# Altium schematic sheet K66_Sheet2.SchDoc
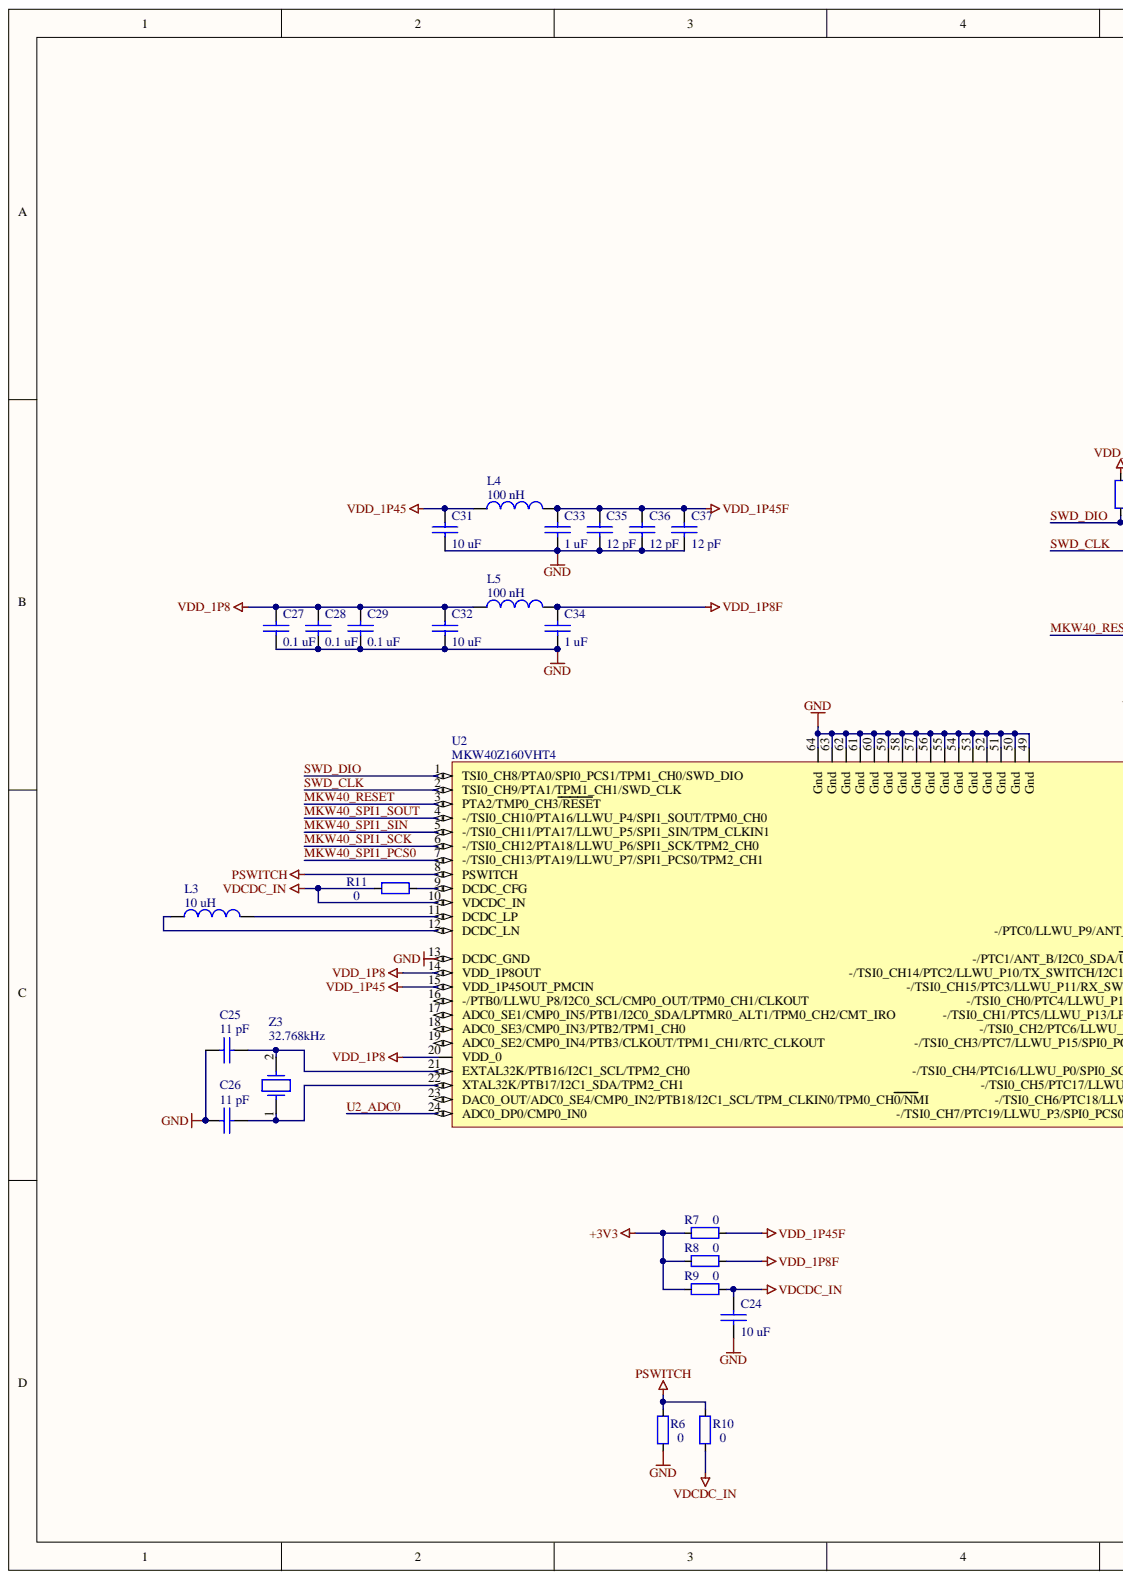
[diagram p0: K66_Sheet2.SchDoc, left]
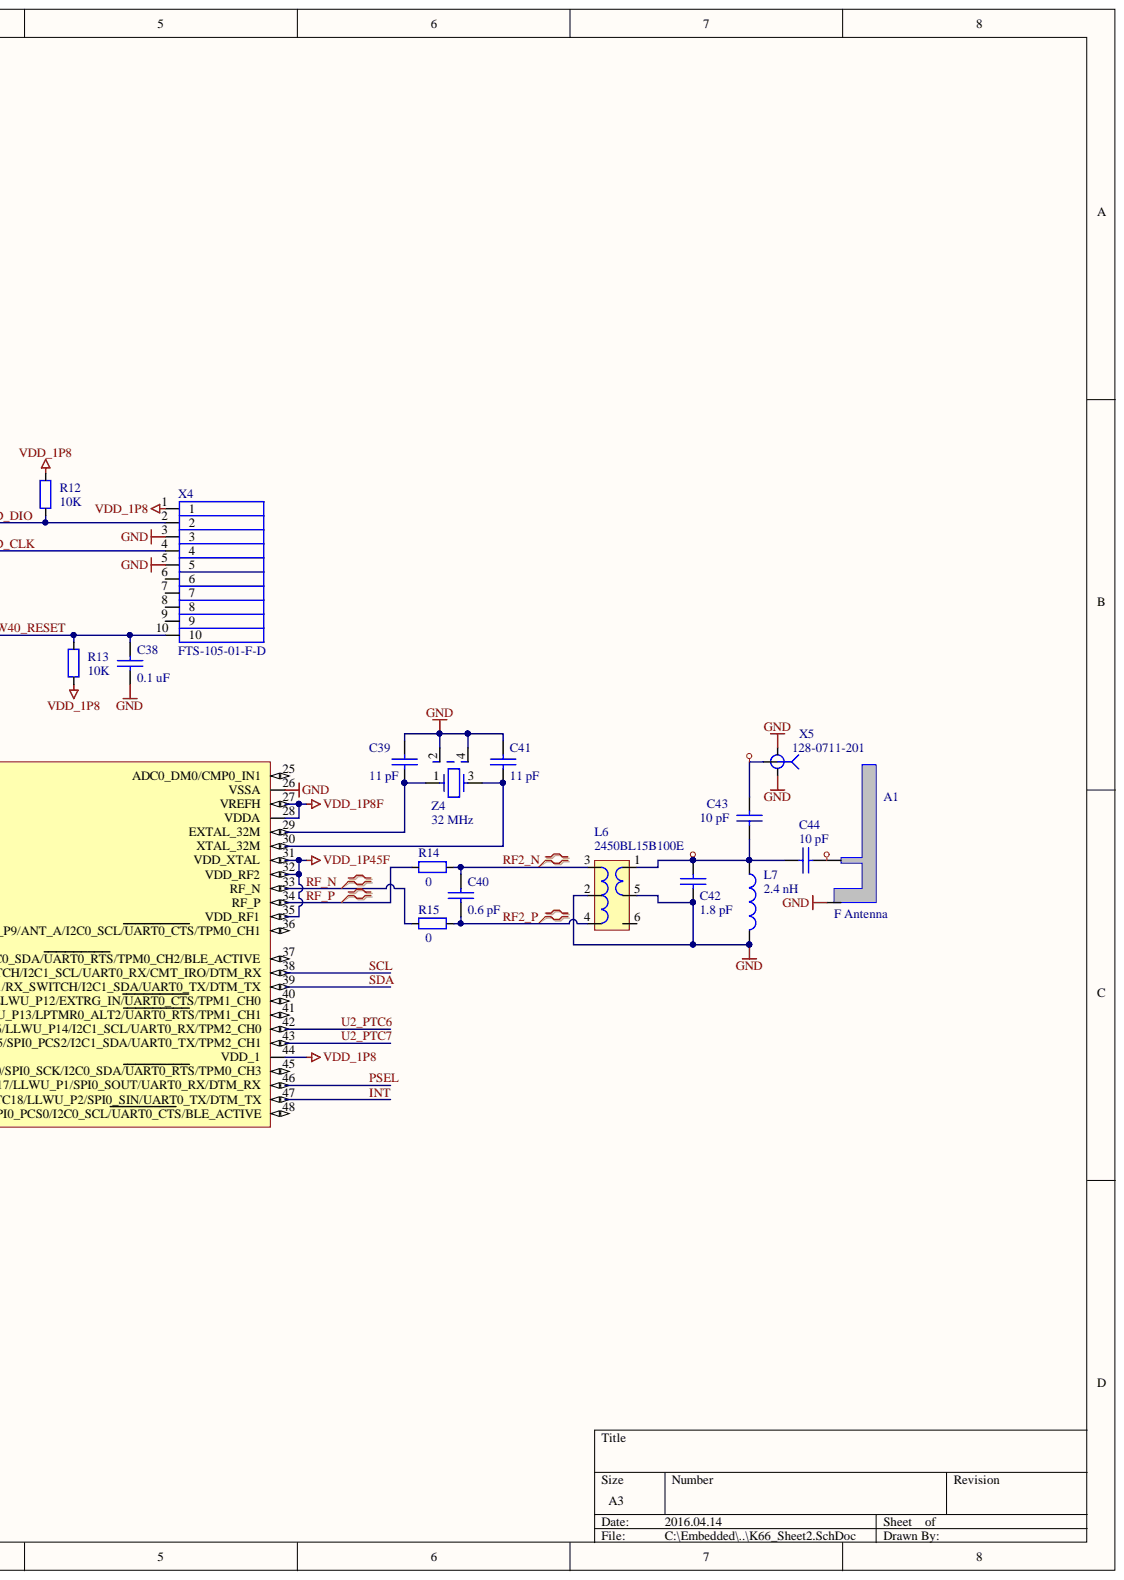
[diagram p1: K66_Sheet2.SchDoc, right]

## Parts
A1  F Antenna  [ZigBee F-antenna]
C24  value 10 uF  [1608[0603]]  (hidden comment: EMK107BBJ106MA-T)
C25  value 11 pF  [1005[0402]]  (hidden comment: 04023U110FAT2A)
C26  value 11 pF  [1005[0402]]  (hidden comment: 04023U110FAT2A)
C27  value 0.1 uF  [1005[0402]]  (hidden comment: C0402C104K8PACTU)
C28  value 0.1 uF  [1005[0402]]  (hidden comment: C0402C104K8PACTU)
C29  value 0.1 uF  [1005[0402]]  (hidden comment: C0402C104K8PACTU)
C31  value 10 uF  [1608[0603]]  (hidden comment: EMK107BBJ106MA-T)
C32  value 10 uF  [1608[0603]]  (hidden comment: EMK107BBJ106MA-T)
C33  value 1 uF  [1608[0603]]  (hidden comment: CL10A105KO8NNNC)
C34  value 1 uF  [1608[0603]]  (hidden comment: CL10A105KO8NNNC)
C35  value 12 pF  [1005[0402]]  (hidden comment: GRM1555C1H120JA01D)
C36  value 12 pF  [1005[0402]]  (hidden comment: GRM1555C1H120JA01D)
C37  value 12 pF  [1005[0402]]  (hidden comment: GRM1555C1H120JA01D)
C38  value 0.1 uF  [1005[0402]]  (hidden comment: C0402C104K8PACTU)
C39  value 11 pF  [1005[0402]]  (hidden comment: 04023U110FAT2A)
C40  value 0.6 pF  [1005[0402]]  (hidden comment: GRM1555C1HR60CA01D)
C41  value 11 pF  [1005[0402]]  (hidden comment: 04023U110FAT2A)
C42  value 1.8 pF  [1005[0402]]  (hidden comment: GRM1555C1H1R8CA01D)
C43  value 10 pF  [1005[0402]]  (hidden comment: 04025A100JAT2A)
C44  value 10 pF  [1005[0402]]  (hidden comment: 04025A100JAT2A)
L3  value 10 uH  [VLS4012ET]  (hidden comment: VLS4012ET-100M)
L4  value 100 nH  [INDC1005-0402]  (hidden comment: MLG1005SR10JT000)
L5  value 100 nH  [INDC1005-0402]  (hidden comment: MLG1005SR10JT000)
L6  2450BL15B100E  [Balun 2450BL15B100]
L7  value 2.4 nH  [INDC1005-0402]  (hidden comment: LQG15HN2N4S02D)
R6  value 0  [0402]  (hidden comment: MCR01MZPJ000)
R7  value 0  [0402]  (hidden comment: MCR01MZPJ000)
R8  value 0  [0402]  (hidden comment: MCR01MZPJ000)
R9  value 0  [0402]  (hidden comment: MCR01MZPJ000)
R10  value 0  [0402]  (hidden comment: MCR01MZPJ000)
R11  value 0  [0402]  (hidden comment: MCR01MZPJ000)
R12  value 10K  [0402]  (hidden comment: MCR01MZPJ103)
R13  value 10K  [0402]  (hidden comment: MCR01MZPJ103)
R14  value 0  [0402]  (hidden comment: MCR01MZPJ000)
R15  value 0  [0402]  (hidden comment: MCR01MZPJ000)
U2  MKW40Z160VHT4  [LQFN 48 MKW40Z]
X4  FTS-105-01-F-D  [FTS-105-01-F-D]
X5  128-0711-201  [128-0711-201]
Z3  value 32.768kHz  [FC-135 Epson]  (hidden comment: FC-135 32.7680KA-A3)
Z4  value 32 MHz  [SMD 3.2mm x 2.5mm]  (hidden comment: NX3225SA-32MHZ-EXS00A-CS02368)

## Nets
+3V3: R7.2 R8.2 R9.2
GND: A1.2 C24.2 C25.1 C26.1 C27.2 C28.2 C29.2 C31.2 C32.2 C33.2 C34.2 C35.2 C36.2 C37.2 C38.2 C39.1 C41.2 C42.2 L6.2 L6.5 L7.2 R6.1 U2.13(DCDC_GND) U2.26(VSSA) U2.49(Gnd) U2.50(Gnd) U2.51(Gnd) U2.52(Gnd) U2.53(Gnd) U2.54(Gnd) U2.55(Gnd) U2.56(Gnd) U2.57(Gnd) U2.58(Gnd) U2.59(Gnd) U2.60(Gnd) U2.61(Gnd) U2.62(Gnd) U2.63(Gnd) U2.64(Gnd) X4.3 X4.5 X5.2 X5.3 Z4.2 Z4.4
PSWITCH: R6.2 R10.2 U2.8(PSWITCH)
VDCDC_IN: C24.1 R9.1 R10.1 R11.1 U2.10(VDCDC_IN)
VDD_1P8: C27.1 C28.1 C29.1 C32.1 L5.1 R12.2 R13.1 U2.14(VDD_1P8OUT) U2.20(VDD_0) U2.44(VDD_1) X4.1
VDD_1P8F: C34.1 L5.2 R8.1 U2.27(VREFH) U2.28(VDDA)
VDD_1P45: C31.1 L4.1 U2.15(VDD_1P45OUT_PMCIN)
VDD_1P45F: C33.1 C35.1 C36.1 C37.1 L4.2 R7.1 U2.31(VDD_XTAL) U2.32(VDD_RF2) U2.35(VDD_RF1)
INT: U2.47(-/TSI0_CH6/PTC18/LLWU_P2/SPI0_SIN/UART0_TX/DTM_TX)
MKW40_RESET: C38.1 R13.2 U2.3(PTA2/TMP0_CH3/RESET) X4.10
MKW40_SPI1_PCS0: U2.7(-/TSI0_CH13/PTA19/LLWU_P7/SPI1_PCS0/TPM2_CH1)
MKW40_SPI1_SCK: U2.6(-/TSI0_CH12/PTA18/LLWU_P6/SPI1_SCK/TPM2_CH0)
MKW40_SPI1_SIN: U2.5(-/TSI0_CH11/PTA17/LLWU_P5/SPI1_SIN/TPM_CLKIN1)
MKW40_SPI1_SOUT: U2.4(-/TSI0_CH10/PTA16/LLWU_P4/SPI1_SOUT/TPM0_CH0)
PSEL: U2.46(-/TSI0_CH5/PTC17/LLWU_P1/SPI0_SOUT/UART0_RX/DTM_RX)
RF2_N: C40.1 L6.3 R14.2
RF2_P: C40.2 L6.4 R15.2
RF_N: R15.1 U2.33(RF_N)
RF_P: R14.1 U2.34(RF_P)
SCL: U2.38(-/TSI0_CH14/PTC2/LLWU_P10/TX_SWITCH/I2C1_SCL/UART0_RX/CMT_IRO/DTM_RX)
SDA: U2.39(-/TSI0_CH15/PTC3/LLWU_P11/RX_SWITCH/I2C1_SDA/UART0_TX/DTM_TX)
SWD_CLK: U2.2(TSI0_CH9/PTA1/TPM1_CH1/SWD_CLK) X4.4
SWD_DIO: R12.1 U2.1(TSI0_CH8/PTA0/SPI0_PCS1/TPM1_CH0/SWD_DIO) X4.2
U2_ADC0: U2.24(ADC0_DP0/CMP0_IN0)
U2_PTC6: U2.42(-/TSI0_CH2/PTC6/LLWU_P14/I2C1_SCL/UART0_RX/TPM2_CH0)
U2_PTC7: U2.43(-/TSI0_CH3/PTC7/LLWU_P15/SPI0_PCS2/I2C1_SDA/UART0_TX/TPM2_CH1)
NetA1_1: A1.1 C44.2
NetC25_2: C25.2 U2.21(EXTAL32K/PTB16/I2C1_SCL/TPM2_CH0) Z3.2
NetC26_2: C26.2 U2.22(XTAL32K/PTB17/I2C1_SDA/TPM2_CH1) Z3.1
NetC39_2: C39.2 U2.29(EXTAL_32M) Z4.1
NetC41_1: C41.1 U2.30(XTAL_32M) Z4.3
NetC42_1: C42.1 C43.2 C44.1 L6.1 L7.1
NetC43_1: C43.1 X5.1
NetL3_1: L3.1 U2.12(DCDC_LN)
NetL3_2: L3.2 U2.11(DCDC_LP)
NetR11_2: R11.2 U2.9(DCDC_CFG)

Not connected: L6 6; U2 16(-/PTB0/LLWU_P8/I2C0_SCL/CMP0_OUT/TPM0_CH1/CLKOUT) 17(ADC0_SE1/CMP0_IN5/PTB1/I2C0_SDA/LPTMR0_ALT1/TPM0_CH2/CMT_IRO) 18(ADC0_SE3/CMP0_IN3/PTB2/TPM1_CH0) 19(ADC0_SE2/CMP0_IN4/PTB3/CLKOUT/TPM1_CH1/RTC_CLKOUT) 23(DAC0_OUT/ADC0_SE4/CMP0_IN2/PTB18/I2C1_SCL/TPM_CLKIN0/TPM0_CH0/NMI) 25(ADC0_DM0/CMP0_IN1) 36(-/PTC0/LLWU_P9/ANT_A/I2C0_SCL/UART0_CTS/TPM0_CH1) 37(-/PTC1/ANT_B/I2C0_SDA/UART0_RTS/TPM0_CH2/BLE_ACTIVE) 40(-/TSI0_CH0/PTC4/LLWU_P12/EXTRG_IN/UART0_CTS/TPM1_CH0) 41(-/TSI0_CH1/PTC5/LLWU_P13/LPTMR0_ALT2/UART0_RTS/TPM1_CH1) 45(-/TSI0_CH4/PTC16/LLWU_P0/SPI0_SCK/I2C0_SDA/UART0_RTS/TPM0_CH3) 48(-/TSI0_CH7/PTC19/LLWU_P3/SPI0_PCS0/I2C0_SCL/UART0_CTS/BLE_ACTIVE); X4 6 7 8 9

## Library data of the parts
C24 C31 C32 = EMK107BBJ106MA-T: 10 uF, 16V, X5R, 20%, 0603, -55°C ~ 85°C; Manufacturer: Taiyo Yuden; PartNum: 587-3238-1-ND; Component Kind: Standard; Distributor: Digi-Key; PartName: EMK107BBJ106MA-T; Tolerance: 20%; Library Name: ea_Capacitors.SCHLIB
C25 C26 C39 C41 = 04023U110FAT2A: 11 pF, 25V, +-1%, NP0/COG, 0402, -55..+125; Manufacturer: AVX Corporation; PartNum: 04023U110FAT2A-ND; Component Kind: Standard; Distributor: Digi-Key; PartName: 04023U110FAT2A; Tolerance: +-1%; Library Name: ea_Capacitors.SCHLIB
C27 = C0402C104K8PACTU: 0.1 uF, 10V, 10% , X5R,  0402, -55°C ~ 85°C; Manufacturer: Kemet; PartNum: 399-3027-1-ND; Tolerance: +-10%; Value: 0.1 uF; Component Kind: Standard; Distributor: DigiKey; PartName: C0402C104K8PACTU; Library Name: ea_Capacitors.SCHLIB
C28 C29 C38 = C0402C104K8PACTU: 0.1 uF, 10V, 10% , X5R,  0402, -55°C ~ 85°C; Manufacturer: Kemet; PartNum: 399-3027-1-ND; Tolerance: +-10%; Component Kind: Standard; Distributor: DigiKey; PartName: C0402C104K8PACTU; Library Name: ea_Capacitors.SCHLIB
C33 C34 = CL10A105KO8NNNC: 1.0 uF, 16V, 10%, X5R, 0603, -55..85 С; Manufacturer: Samsung; PartNum: 1276-1034-1-ND; Component Kind: Standard; Distributor: Digi-Key; PartName: CL10A105KO8NNNC; Tolerance: 10%; Library Name: ea_Capacitors.SCHLIB
C35 = GRM1555C1H120JA01D: 12 pF, 50V, 5%, NP0/COG, 0402, -55..+125; Manufacturer: Murata Electronics North America; PartNum: 490-5924-1-ND; Value: 12 pF; Component Kind: Standard; Distributor: Digi-Key; PartName: GRM1555C1H120JA01D; Tolerance: 5%; Library Name: ea_Capacitors.SCHLIB
C36 C37 = GRM1555C1H120JA01D: 12 pF, 50V, 5%, NP0/COG, 0402, -55..+125; Manufacturer: Murata Electronics North America; PartNum: 490-5924-1-ND; Component Kind: Standard; Distributor: Digi-Key; PartName: GRM1555C1H120JA01D; Tolerance: 5%; Library Name: ea_Capacitors.SCHLIB
C40 = GRM1555C1HR60CA01D: 0.6 pF, 50V, +-0.25 pF, NP0/COG, 0402, -55..+125; Manufacturer: Murata Electronics North America; PartNum: GRM1555C1HR60CA01D-ND; Component Kind: Standard; Distributor: Digi-Key; PartName: GRM1555C1HR60CA01D; Tolerance: +-0.25 pF; Library Name: ea_Capacitors.SCHLIB
C42 = GRM1555C1H1R8CA01D: 1.8 pF, 50V, +-0.25 pF, NP0/COG, 0402, -55..+125; Manufacturer: Murata Electronics North America; PartNum: 490-5898-1-ND; Component Kind: Standard; Distributor: Digi-Key; PartName: GRM1555C1H1R8CA01D; Tolerance: +-0.25 pF; Library Name: ea_Capacitors.SCHLIB
C43 C44 = 04025A100JAT2A: 10 pF, 50V, +-5%, NP0/COG, 0402, -55..+125; Manufacturer: AVX Corporation; PartNum: 478-1070-1-ND; Component Kind: Standard; Distributor: Digi-Key; PartName: 04025A100JAT2A; Tolerance: +-5%; Library Name: ea_Capacitors.SCHLIB
L3 = VLS4012ET-100M: 10uH, 890 mA, 0.228 Ohm, +-20%,- 40 C to + 105 C; Manufacturer: TDK Corporation; PartNum: 445-6681-1-ND; Tolerance: ±20%; Component Kind: Standard; Distributor: Mouser; PartName: VLS4012ET-100M; Library Name: ea_Inductors.SCHLIB
L4 L5 = MLG1005SR10JT000: 100nH, ±5%, 200 мА, 2 Ohm, 0402, -55..+125 C; Manufacturer: TDK Corporation; PartNum: 445-3071-1-ND; Component Kind: Standard; Distributor: Digi-Key; PartName: MLG1005SR10JT000; Tolerance: ±5%; Library Name: ea_Inductors.SCHLIB
L6 = 2450BL15B100E: BALUN 2.4GHZ WIFI/BLUETOOTH; Manufacturer: Johanson Technology Inc.; PartNum: 712-1041-1-ND; Component Kind: Standard; Distributor: Digi-Key; PartName: 2450BL15B100E; Price: 0.35$; Library Name: ea_IC_ZigBee.SchLib
L7 = LQG15HN2N4S02D: 2.4 nH, +-0.3 nH,  0402, -55..+125 C; Manufacturer: Murata Electronics North America; PartNum: 490-6559-1-ND; Component Kind: Standard; Distributor: Digi-Key; PartName: LQG15HN2N4S02D; Tolerance: +-0.3 nH; Library Name: ea_Inductors.SCHLIB
R6-R11 R14 R15 = MCR01MZPJ000: 0 Ohm, 0402, Thick Film, -55..+155; Manufacturer: Rohm Semiconductor; PartNum: RHM0.0JCT-ND; Component Kind: Standard; Distributor: Digi-Key; PartName: MCR01MZPJ000; Price: 0.1$; Library Name: ea_Resistors.SCHLIB
R12 R13 = MCR01MZPJ103: 10 K, 0402, Thick Film, -55..+155; Manufacturer: Rohm Semiconductor; PartNum: RHM10KJTR-ND; Tolerance: 5%; Component Kind: Standard; Distributor: Digi-Key; PartName: MCR01MZPJ103; Price: 0.1$; Library Name: ea_Resistors.SCHLIB
U2 = MKW40Z160VHT4: Manufacturer: Freescale; Component Kind: Standard; Distributor: Digi-Key; PartName: MKW40Z160VHT4; PartNum: MKW40Z160VHT4; Library Name: ea_IC_ZigBee.SchLib
X4 = FTS-105-01-F-D: Board-To-Board Connector, Vertical, FTS Series, Through Hole, Header, 10, 1.27 mm; Manufacturer: SAMTEC; PartNum: 1928242; Component Kind: Standard; Distributor: Farnell; PartName: FTS-105-01-F-D; Library Name: ea_JTAG_SWD.SCHLIB
X5 = 128-0711-201: CONN UMC RCPT STR 50 OHM SMD; Manufacturer: Cinch Connectivity Solutions Johnson; PartNum: J983CT-ND; Component Kind: Standard; Distributor: Digi-Key; PartName: 128-0711-201; Library Name: ea_Connectors.SCHLIB
Z3 = FC-135 32.7680KA-A3: 32.768kHz ±20ppm Crystal 12.5pF 70 kOhm -40°C ~ 85°C Surface Mount 2-SMD; Manufacturer: EPSON; PartNum: SE2413CT-ND; Component Kind: Standard; Distributor: Digi-Key; PartName: FC-135 32.7680KA-A3; Tolerance: ±20ppm; Library Name: ea_OSC_CLK_GEN.SCHLIB
Z4 = NX3225SA-32MHZ-EXS00A-CS02368: 32 MHz, 9 pF, +-16 ppm, -40..+85 С; Manufacturer: NDK; PartNum: 644-1049-1-ND; Tolerance: 16 ppm; Component Kind: Standard; Distributor: Digi-Key; PartName: NX3225SA-32MHZ-EXS00A-CS02368; Library Name: ea_OSC_CLK_GEN.SCHLIB
A1 = F Antenna: Component Kind: Net Tie; Description: F Antenna; Library Name: ea_Antennas.SchLib
